# Altium schematic sheet CoProcessor.SchDoc(CoProcessor)
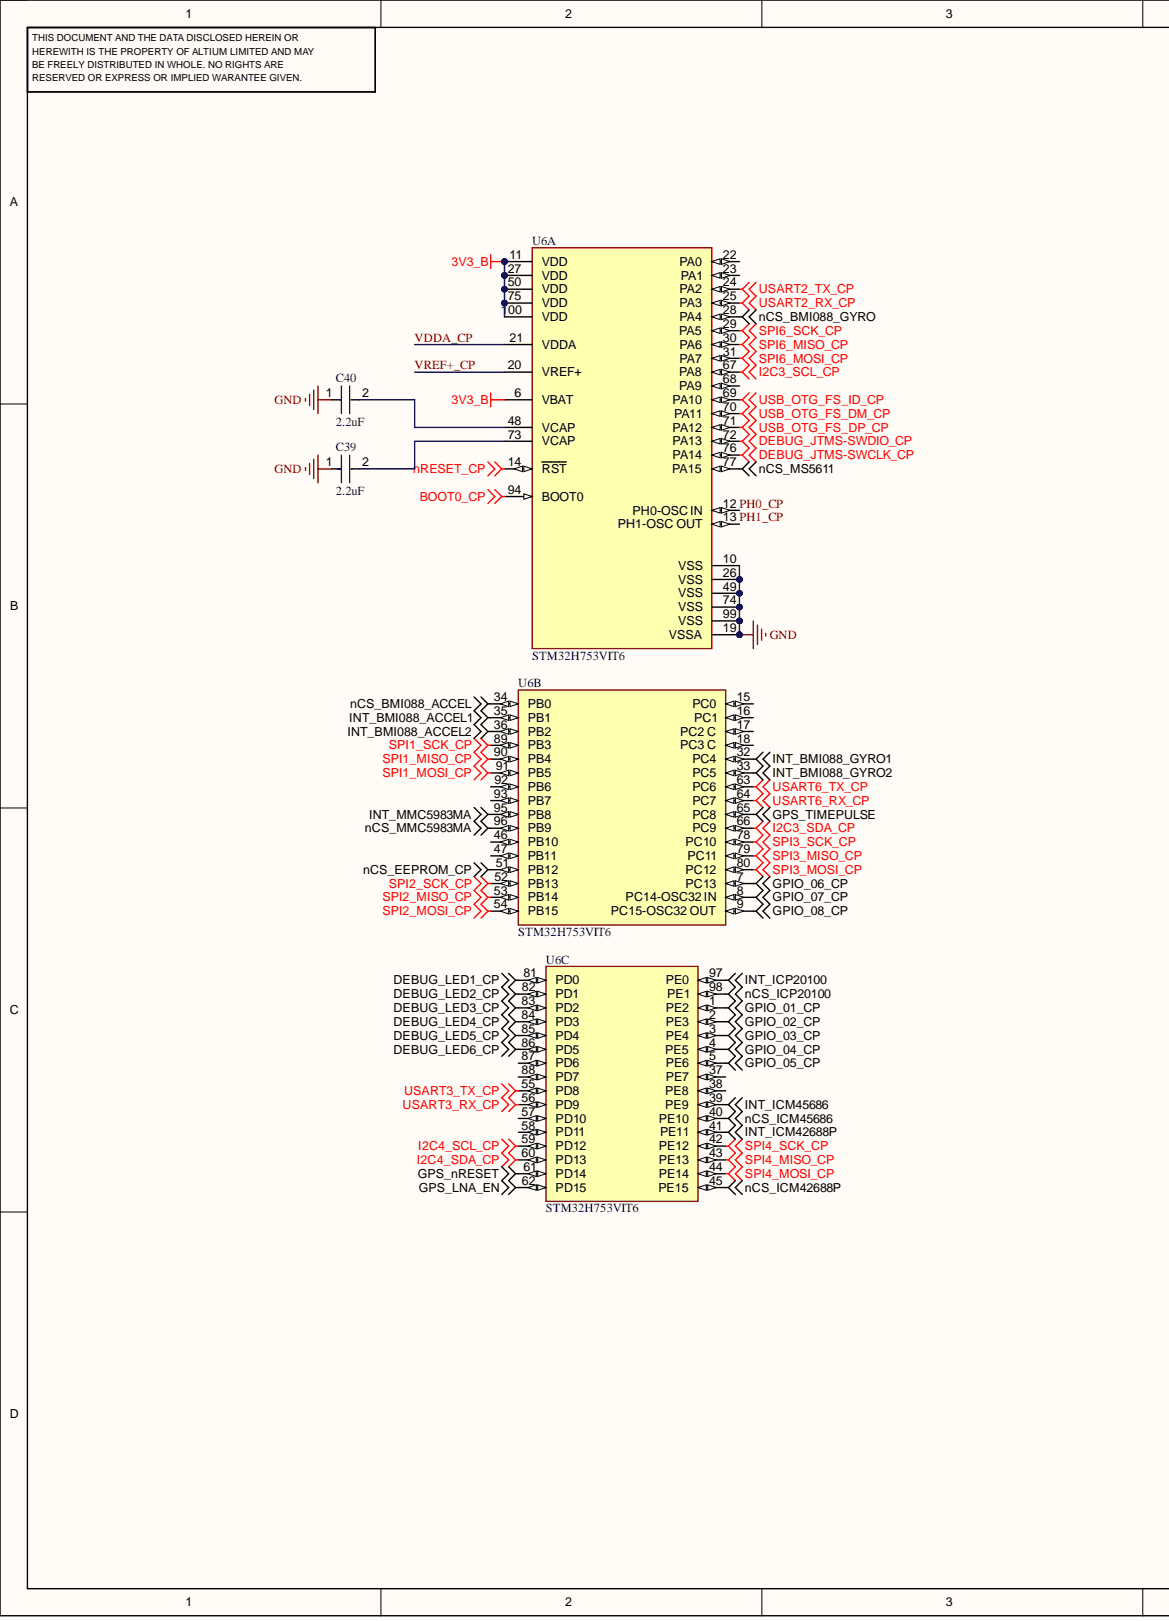
[diagram p0: CoProcessor.SchDoc, left]
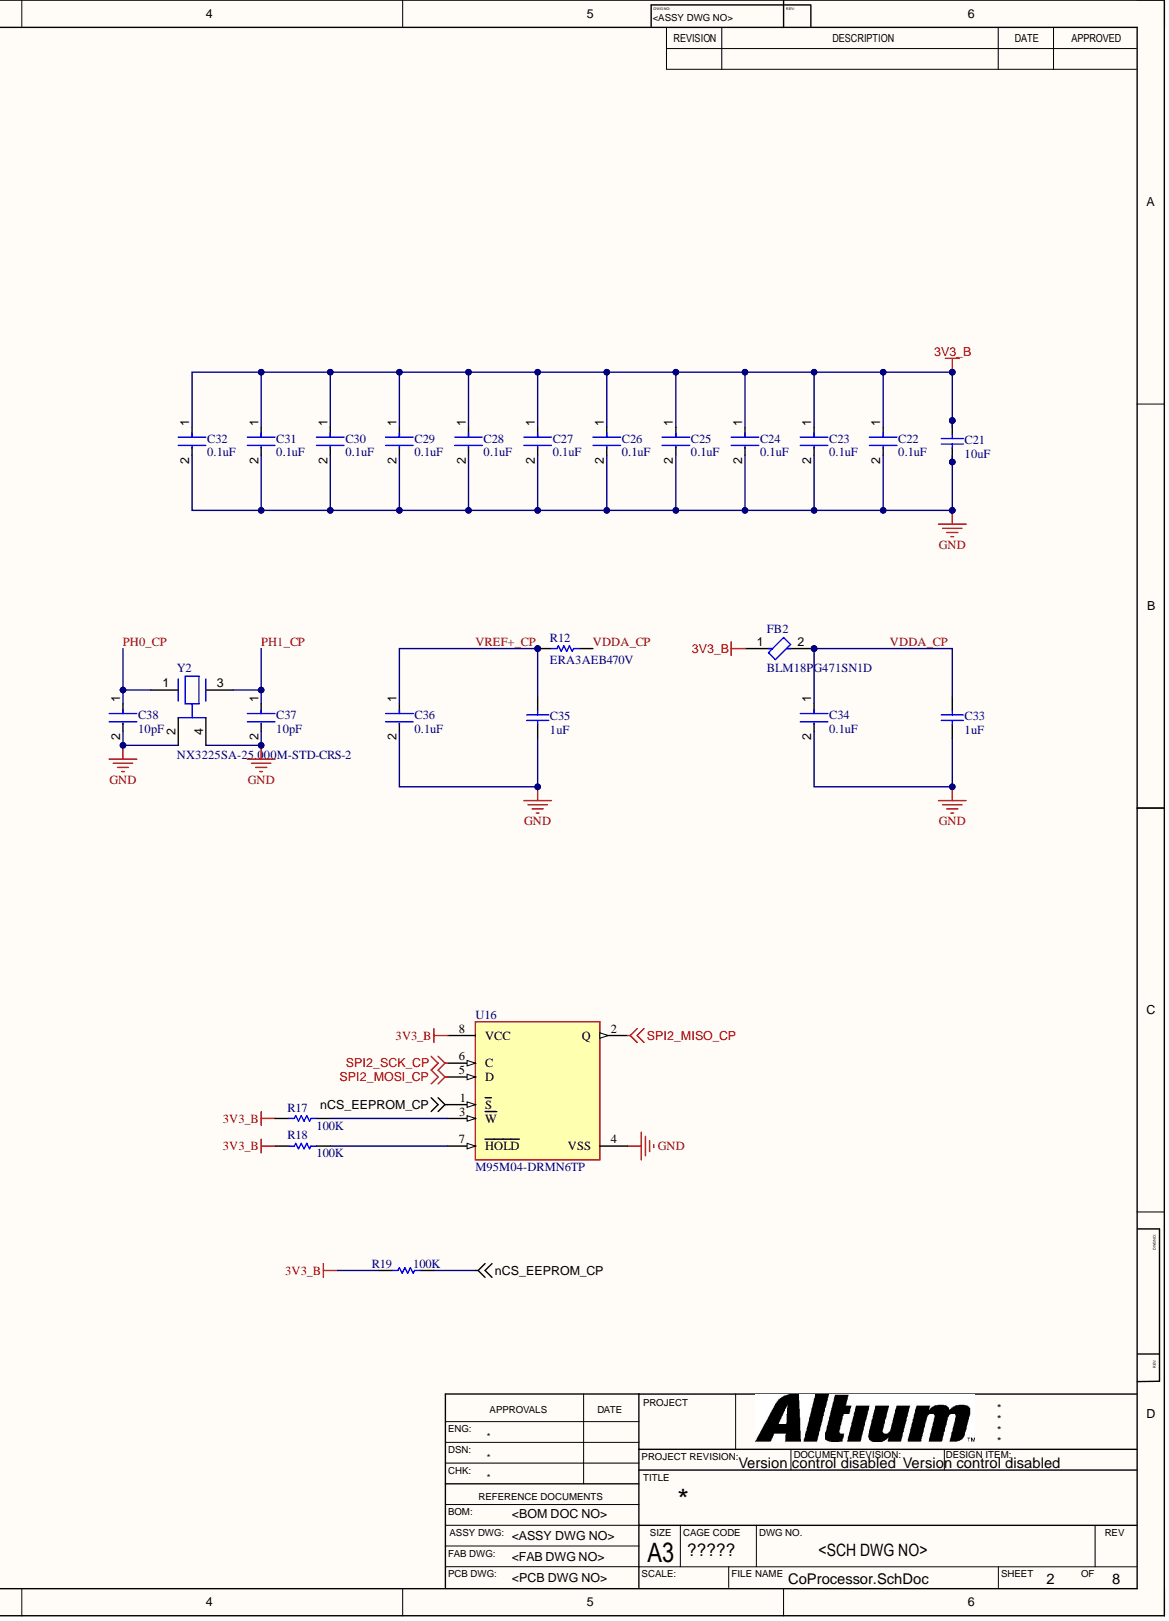
[diagram p1: CoProcessor.SchDoc, right]

## Parts
C21  10uF
C22  0.1uF
C23  0.1uF
C24  0.1uF
C25  0.1uF
C26  0.1uF
C27  0.1uF
C28  0.1uF
C29  0.1uF
C30  0.1uF
C31  0.1uF
C32  0.1uF
C33  1uF
C34  0.1uF
C35  1uF
C36  0.1uF
C37  10pF
C38  10pF
C39  2.2uF
C40  2.2uF
FB2  BLM18PG471SN1D
R12  ERA3AEB470V
R17  100K
R18  100K
R19  100K
U6  STM32H753VIT6  (parts A,B,C)
U16  M95M04-DRMN6TP
Y2  NX3225SA-25.000M-STD-CRS-2

## Nets
3V3_B: C21.1 C22.1 C23.1 C24.1 C25.1 C26.1 C27.1 C28.1 C29.1 C30.1 C31.1 C32.1 FB2.1 R17.2 R18.2 R19.2 U6.6(VBAT) U6.11(VDD) U6.27(VDD) U6.50(VDD) U6.75(VDD) U6.100(VDD) U16.8(VCC)
BOOT0_CP: U6.94(BOOT0)
DEBUG_JTMS-SWCLK_CP: U6.76(PA14)
DEBUG_JTMS-SWDIO_CP: U6.72(PA13)
DEBUG_LED1_CP: U6.81(PD0)
DEBUG_LED2_CP: U6.82(PD1)
DEBUG_LED3_CP: U6.83(PD2)
DEBUG_LED4_CP: U6.84(PD3)
DEBUG_LED5_CP: U6.85(PD4)
DEBUG_LED6_CP: U6.86(PD5)
GND: C21.2 C22.2 C23.2 C24.2 C25.2 C26.2 C27.2 C28.2 C29.2 C30.2 C31.2 C32.2 C33.2 C34.2 C35.2 C36.2 C37.2 C38.2 C39.1 C40.1 U6.10(VSS) U6.19(VSSA) U6.26(VSS) U6.49(VSS) U6.74(VSS) U6.99(VSS) U16.4(VSS) Y2.2 Y2.4
GPIO_01_CP: U6.1(PE2)
GPIO_02_CP: U6.2(PE3)
GPIO_03_CP: U6.3(PE4)
GPIO_04_CP: U6.4(PE5)
GPIO_05_CP: U6.5(PE6)
GPIO_06_CP: U6.7(PC13)
GPIO_07_CP: U6.8(PC14-OSC32_IN)
GPIO_08_CP: U6.9(PC15-OSC32_OUT)
GPS_LNA_EN: U6.62(PD15)
GPS_nRESET: U6.61(PD14)
GPS_TIMEPULSE: U6.65(PC8)
I2C3_SCL_CP: U6.67(PA8)
I2C3_SDA_CP: U6.66(PC9)
I2C4_SCL_CP: U6.59(PD12)
I2C4_SDA_CP: U6.60(PD13)
INT_BMI088_ACCEL1: U6.35(PB1)
INT_BMI088_ACCEL2: U6.36(PB2)
INT_BMI088_GYRO1: U6.32(PC4)
INT_BMI088_GYRO2: U6.33(PC5)
INT_ICM42688P: U6.41(PE11)
INT_ICM45686: U6.39(PE9)
INT_ICP20100: U6.97(PE0)
INT_MMC5983MA: U6.95(PB8)
nCS_BMI088_ACCEL: U6.34(PB0)
nCS_BMI088_GYRO: U6.28(PA4)
nCS_EEPROM_CP: R19.1 U6.51(PB12) U16.1(S)
nCS_ICM42688P: U6.45(PE15)
nCS_ICM45686: U6.40(PE10)
nCS_ICP20100: U6.98(PE1)
nCS_MMC5983MA: U6.96(PB9)
nCS_MS5611: U6.77(PA15)
nRESET_CP: U6.14(RST)
SPI1_MISO_CP: U6.90(PB4)
SPI1_MOSI_CP: U6.91(PB5)
SPI1_SCK_CP: U6.89(PB3)
SPI2_MISO_CP: U6.53(PB14) U16.2(Q)
SPI2_MOSI_CP: U6.54(PB15) U16.5(D)
SPI2_SCK_CP: U6.52(PB13) U16.6(C)
SPI3_MISO_CP: U6.79(PC11)
SPI3_MOSI_CP: U6.80(PC12)
SPI3_SCK_CP: U6.78(PC10)
SPI4_MISO_CP: U6.43(PE13)
SPI4_MOSI_CP: U6.44(PE14)
SPI4_SCK_CP: U6.42(PE12)
SPI6_MISO_CP: U6.30(PA6)
SPI6_MOSI_CP: U6.31(PA7)
SPI6_SCK_CP: U6.29(PA5)
USART2_RX_CP: U6.25(PA3)
USART2_TX_CP: U6.24(PA2)
USART3_RX_CP: U6.56(PD9)
USART3_TX_CP: U6.55(PD8)
USART6_RX_CP: U6.64(PC7)
USART6_TX_CP: U6.63(PC6)
USB_OTG_FS_DM_CP: U6.70(PA11)
USB_OTG_FS_DP_CP: U6.71(PA12)
USB_OTG_FS_ID_CP: U6.69(PA10)
PH0_CP: C38.1 U6.12(PH0-OSC_IN) Y2.1
PH1_CP: C37.1 U6.13(PH1-OSC_OUT) Y2.3
VDDA_CP: C33.1 C34.1 FB2.2 R12.2 U6.21(VDDA)
VREF+_CP: C35.1 C36.1 R12.1 U6.20(VREF+)
NetC39_2: C39.2 U6.73(VCAP)
NetC40_2: C40.2 U6.48(VCAP)
NetR17_1: R17.1 U16.3(W)
NetR18_1: R18.1 U16.7(HOLD)

Not connected: U6 15(PC0) 16(PC1) 17(PC2_C) 18(PC3_C) 22(PA0) 23(PA1) 37(PE7) 38(PE8) 46(PB10) 47(PB11) 57(PD10) 58(PD11) 68(PA9) 87(PD6) 88(PD7) 92(PB6) 93(PB7)

## Library data of the parts
C21 = CMP-2007-01044-1: Manufacturer: Kemet; Part Number: C0805C106K8RACTU; Component Kind: Standard; Library Name: Altium Content Vault
C22-C32 C34 C36 = CMP-2006-03061-2: CAP CER 0.1UF 50V X7R 0603; Manufacturer: KEMET; Manufacturer Part Number: C0603C104K5RACTU; Automotive Grade: Grade 1; Capacitance: 0.10 μF; Material: Ceramic; Temperature Coefficient: 30ppm/°C; Tolerance: 0.1; Value: 0.10 μF; Automotive: Yes; Category: Cap; Component Kind: Standard; ComponentLink2Description: Datasheet; ComponentLink2URL: https://api.kemet.com/component-edge/download/datasheet/C0603C100J5GACAUTO.pdf; Device Class L1: Passive Components; Device Class L2: Capacitors; Device Class L3: Ceramic Capacitors; Digikey Description: CAP CER 0.1UF 50V X7R 0603; Height: 0.87mm; Lead Free: Yes; Library Name: Altium Content Vault; Octopart: https://octopart.com/c0603c104k5ractu-kemet-139244; Package: C0603C-CF; RoHS: TRUE; Temperature: -55°C to +125°C; Temperature Characteristic: X7R; Temperature Range High: +125°C; Temperature Range Low: -55°C; Voltage Rating: 50 V
C33 C35 = CMP-2006-02298-2: Manufacturer: Kemet; Part Number: C0603C105K4RACTU; Component Kind: Standard; Library Name: Altium Content Vault
C37 C38 = CMP-2006-03343-2: CAP CER 10PF 50V NP0 0603; Manufacturer: KEMET; Manufacturer Part Number: C0603C100C5GACTU; Automotive Grade: Grade 1; Material: Ceramic; Temperature Characteristic: C0G; Temperature Coefficient: 30ppm/°C; Tolerance: 0.25 pF; Automotive: Yes; Capacitance: 10 pF; Category: Cap; Component Kind: Standard; ComponentLink2Description: Datasheet; ComponentLink2URL: https://api.kemet.com/component-edge/download/datasheet/C0603C100J5GACAUTO.pdf; Device Class L1: Passive Components; Device Class L2: Capacitors; Device Class L3: Ceramic Capacitors; Digikey Description: CAP CER 10PF 50V NP0 0603; Height: 0.87mm; Lead Free: Yes; Library Name: Altium Content Vault; Mouser Description: Multilayer Ceramic Capacitors MLCC - SMD/SMT 50volts 10pF C0G 0.25%; Octopart: https://octopart.com/c0603c100c5gactu-kemet-9138556; Package: C0603C-CF; RoHS: TRUE; Temperature: -55°C to +125°C; Temperature Range High: +125°C; Temperature Range Low: -55°C; Value: 10 pF; Voltage Rating: 50 V
C39 C40 = CMP-2006-02836-2: Chip Multilayer Ceramic Capacitors for General Purpose, 0603, 2.2uF, X7R, 15%, 10%, 10V; Capacitance: 2.2uF; Case Code (Imperial): 0603; Case Code (Metric): 1608; Case/Package: 0603; Component Kind: Standard; Depth: 0.8mm; Dielectric: X7R; Height: 0.8mm; Lead Free: Lead Free; Length: 1.6mm; Library Name: Altium Content Vault; Material: Ceramic; Max Operating Temperature: 125°C; Min Operating Temperature: -55°C; Mount: Surface Mount; Number of Pins: 2; Package Quantity: 1; Packaging: Cut Tape; REACH SVHC: No SVHC; Termination: SMD/SMT; Thickness: 0.035inch; Tolerance: 10%; Voltage: 10V; Voltage Rating: 10V; Voltage Rating (DC): 10V; Weight: 7.1E-05oz; Width: 0.031inch
FB2 = CMP-0686-00587-3: Chip Ferrite Bead, 0603, 470Ω @ 100MHz, 0.2Ω, 25%, 1A; DC Resistance (DCR): 200mR; Impedance: 470R; Material: Steel; Number of Circuits: 1; Case Code (Imperial): 0603; Case Code (Metric): 1608; Case/Package: 0603; Component Kind: Standard; Core Material: Ferrite; Current Rating: 1A; DC Current: 1A; DC Resistance-Max: 200mR; Depth: 0.8mm; Height: 0.8mm; Lead Free: Lead Free; Length: 1.6mm; Library Name: Altium Content Vault; Max Current Rating: 1A; Max DC Current: 1A; Max Operating Temperature: 125°C; Military Standard: Not; Min Operating Temperature: -55°C; Number of Lines: 1; Number of Pins: 2; Package Quantity: 1; Packaging: Tape and Reel; Radiation Hardening: No; REACH SVHC: No SVHC; Resistance: 200mR; RoHS Compliant: Yes; Series: BLM18P; Termination: SMD/SMT; Test Frequency: 100MHz; Tolerance: 25%; Width: 0.8mm
R12 = CMP-2000-00070-1: Manufacturer: Panasonic; Component Kind: Standard; Library Name: Altium Content Vault; Part Number: ERA3AEB470V
R17-R19 = CMP-2000-01852-1: Manufacturer: KOA Speer; Part Number: RK73B1JTTD104J; Component Kind: Standard; Library Name: Altium Content Vault
U6 = CMP-12105-000037-1: LQFP 100 14X14X1.4; Manufacturer: STMicroelectronics; Core Architecture: ARM Cortex-M7; Data Bus Width: 32bits; Frequency: 480MHz; Interface: I2C,SPI,UART,USART,CAN,USB,Ethernet,I2S,Other; Max Junction Temp: +125°C; Max Supply Voltage: 3.6V; Memory Size: 2MBytes; Memory Type: FLASH; Min Supply Voltage: 1.71V; Number Of A/D Converters: 5; Number Of Adc Channels: 36; Number Of Cores: 1; Number Of I2C Channels: 4; Number Of I/Os: 82; Number Of Pwm Channels: 2; Number Of Spi Channels: 6; Number Of Timers/Counters: 20; Ram Size: 1MBytes; Standoff Height: 0.05mm; Ambient Temperature Range High: +85°C; Ambient Temperature Range Low: -40°C; Automotive: No; Category: IC; Component Kind: Standard; ComponentLink2Description: Datasheet; ComponentLink2URL: https://www.st.com/resource/en/datasheet/stm32h753vi.pdf; Device Class L1: Integrated Circuits (ICs); Device Class L2: Embedded Processors and Controllers; Device Class L3: Microcontrollers; Digikey Description: LQFP 100 14X14X1.4; Height: 1.6mm; Ipc Land Pattern Name: QFP50P1600X1600X160-100; Lead Free: Yes; Library Name: Altium Content Vault; Manufacturer Part Number: STM32H753VIT6; Mouser Description: MCU 32-Bit STM32 ARM Cortex M7 RISC 2MB Flash 1.8V/2.5V/3.3V 100-Pin LQFP Tray; Number Of Uart Channels: 4; Octopart: https://octopart.com/stm32h753vit6-stmicroelectronics-87879769; Package: LQFP100-1L; RoHS: TRUE; Temperature: -40°C to +85°C; Temperature Range High: +85°C; Temperature Range Low: -40°C
U16 = CMP-12142-000020-1: STANDARD SERIAL EEPROM; Component Kind: Standard; Library Name: Altium Content Vault; RoHS Compliant: Yes
Y2 = CMP-200220-000002-1: CRYSTAL 25.0000MHZ 8PF SMD; Manufacturer: NDK; Automotive Grade: Grade 1; Esr: 100Ω; Frequency: 25MHz; Tolerance: 15ppm; Automotive: Yes; Category: Crys; Component Kind: Standard; ComponentLink2Description: Datasheet; ComponentLink2URL: https://www.ndk.com/images/products/catalog/c_NX3225SA-STD-CRS-2_e.pdf; Device Class L1: Passive Components; Device Class L2: Crystals / Oscillators; Device Class L3: Crystals; Digikey Description: CRYSTAL 25.0000MHZ 8PF SMD; Height: 0.6mm; Lead Free: Yes; Library Name: Altium Content Vault; Load Capacitance: 8pF; Manufacturer Part Number: NX3225SA-25.000M-STD-CRS-2; Octopart: https://octopart.com/nx3225sa-25.000m-std-crs-2-ndk-51374293; Package: NX3225SA; RoHS: TRUE; Series: NX3225SA; Temperature: -40°C to +125°C; Temperature Range High: +125°C; Temperature Range Low: -40°C
